# Altium schematic sheet RF_Front-End1.SchDoc
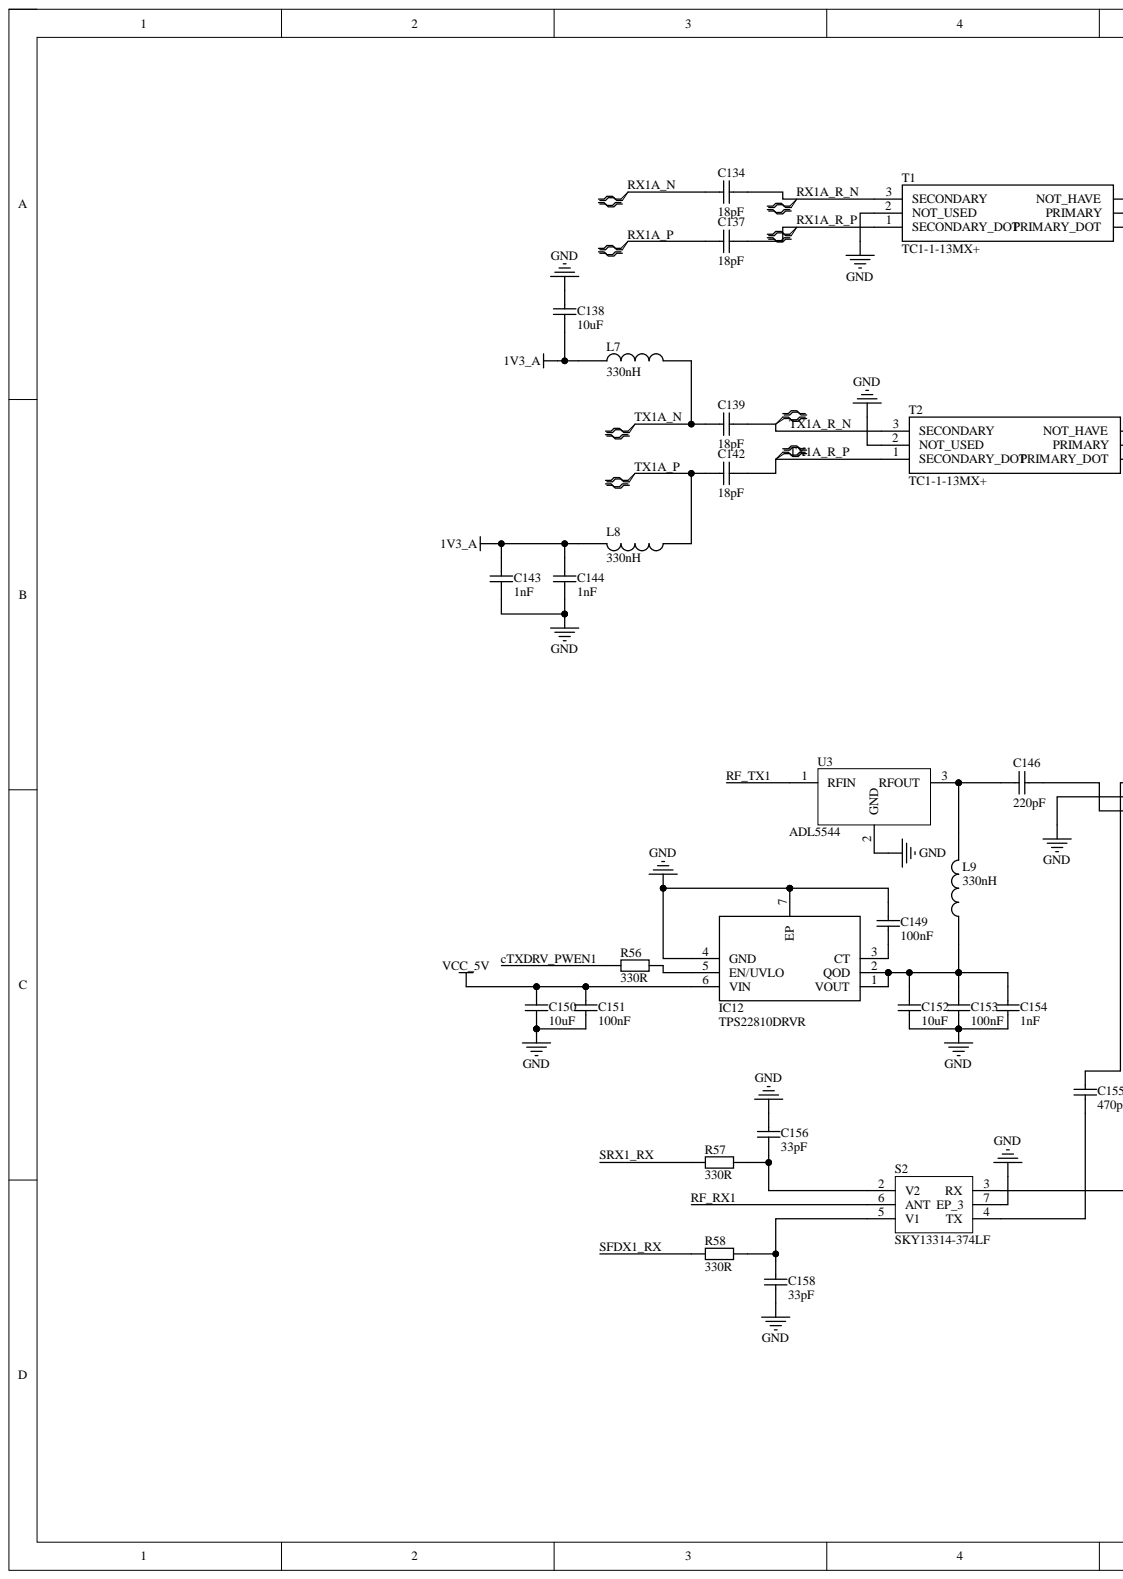
[diagram p0: RF_Front-End1.SchDoc, left]
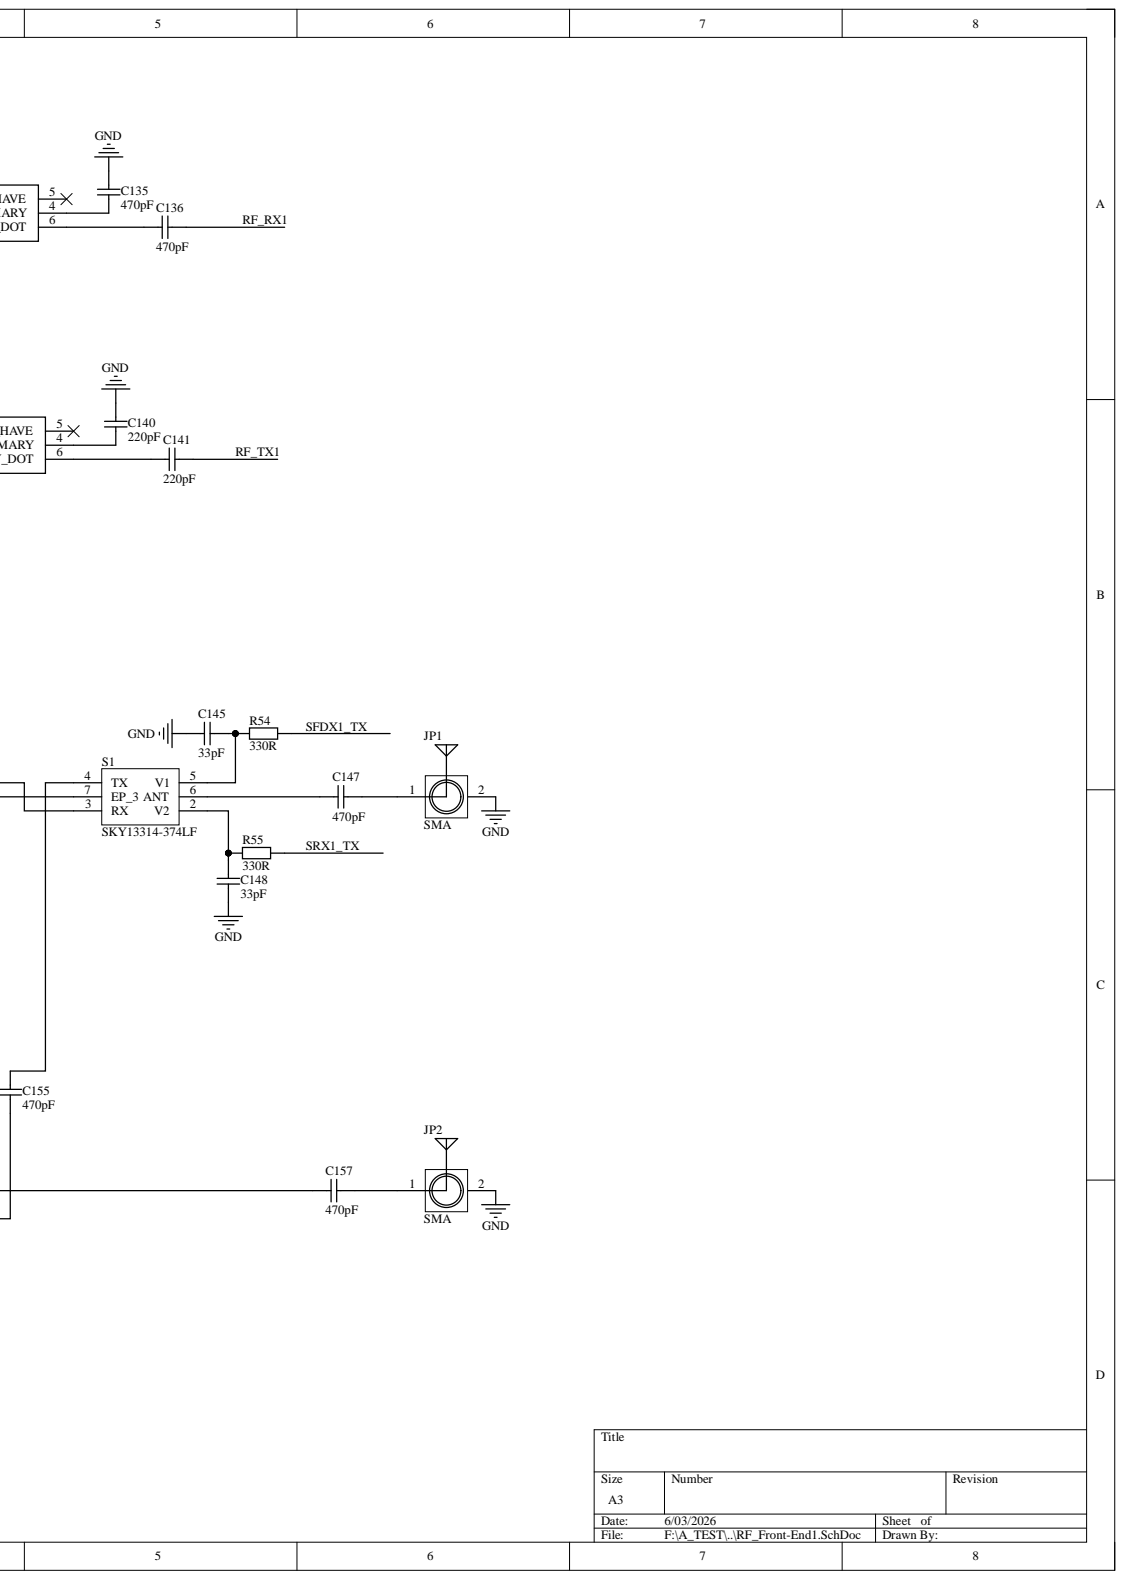
[diagram p1: RF_Front-End1.SchDoc, right]

## Parts
C134  18pF  [C 0402_L]
C135  470pF  [C 0402_L]
C136  470pF  [C 0402_L]
C137  18pF  [C 0402_L]
C138  10uF  [C 0402_L]
C139  18pF  [C 0402_L]
C140  220pF  [C 0402_L]
C141  220pF  [C 0402_L]
C142  18pF  [C 0402_L]
C143  1nF  [C 0402_L]
C144  1nF  [C 0402_L]
C145  33pF  [C 0402_L]
C146  220pF  [C 0402_L]
C147  470pF  [C 0402_L]
C148  33pF  [C 0402_L]
C149  100nF  [C 0402_L]
C150  10uF  [C 0603_L]
C151  100nF  [C 0402_L]
C152  10uF  [C 0603_L]
C153  100nF  [C 0402_L]
C154  1nF  [C 0402_L]
C155  470pF  [C 0402_L]
C156  33pF  [C 0402_L]
C157  470pF  [C 0402_L]
C158  33pF  [C 0402_L]
IC12  TPS22810DRVR  [SON65P200X200X80-7N]
JP1  SMA  [SMA-KE - 0.5mm]
JP2  SMA  [SMA-KE - 0.5mm]
L7  330nH  [L 0402]
L8  330nH  [L 0402]
L9  330nH  [L 0402]
R54  330R  [R 0402_L]
R55  330R  [R 0402_L]
R56  330R  [R 0402_L]
R57  330R  [R 0402_L]
R58  330R  [R 0402_L]
S1  SKY13314-374LF  [SKY13314374LF]
S2  SKY13314-374LF  [SKY13314374LF]
T1  TC1-1-13MX+  [TC414]
T2  TC1-1-13MX+  [TC414]
U3  ADL5544  [SOT89]

## Nets
1V3_A: C138.1 C143.1 C144.1 L7.1 L8.2
GND: C135.1 C138.2 C140.1 C143.2 C144.2 C145.2 C148.2 C149.2 C150.1 C151.1 C152.1 C153.1 C154.1 C156.2 C158.2 IC12.4(GND) IC12.7(EP) JP1.2 JP2.2 S1.7(EP_3) S2.7(EP_3) T1.2(NOT_USED) T2.2(NOT_USED) U3.2
VCC_5V: C150.2 C151.2 IC12.6(VIN)
cTXDRV_PWEN1: R56.1
RF_RX1: C136.2 S2.6(ANT)
RF_TX1: C141.2 U3.1
RX1A_N: C134.1
RX1A_P: C137.1
RX1A_R_N: C134.2 T1.3(SECONDARY)
RX1A_R_P: C137.2 T1.1(SECONDARY_DOT)
SFDX1_RX: R58.1
SFDX1_TX: R54.2
SRX1_RX: R57.1
SRX1_TX: R55.2
TX1A_N: C139.1 L7.2
TX1A_P: C142.1 L8.1
TX1A_R_N: C139.2 T2.3(SECONDARY)
TX1A_R_P: C142.2 T2.1(SECONDARY_DOT)
NetC135_2: C135.2 T1.4(PRIMARY)
NetC136_1: C136.1 T1.6(PRIMARY_DOT)
NetC140_2: C140.2 T2.4(PRIMARY)
NetC141_1: C141.1 T2.6(PRIMARY_DOT)
NetC145_1: C145.1 R54.1 S1.5(V1)
NetC146_1: C146.1 S1.3(RX)
NetC146_2: C146.2 L9.2 U3.3
NetC147_1: C147.1 JP1.1
NetC147_2: C147.2 S1.6(ANT)
NetC148_1: C148.1 R55.1 S1.2(V2)
NetC149_1: C149.1 IC12.3(CT)
NetC152_2: C152.2 C153.2 C154.2 IC12.1(VOUT) IC12.2(QOD) L9.1
NetC155_1: C155.1 S1.4(TX)
NetC155_2: C155.2 S2.4(TX)
NetC156_1: C156.1 R57.2 S2.2(V2)
NetC157_1: C157.1 JP2.1
NetC157_2: C157.2 S2.3(RX)
NetC158_1: C158.1 R58.2 S2.5(V1)
NetIC12_5: IC12.5(EN/UVLO) R56.2

Not connected: T1 5(NOT_HAVE); T2 5(NOT_HAVE)

## Library data of the parts
C134-C158 = Cap: 贴片电容; Component Kind: Standard; Library Name: A_Schlib.SchLib
  C134 C137 C139 C142: value: 18pF
  C135 C136 C147 C155 C157: value: 470pF
  C138 C150 C152: value: 10uF
  C140 C141 C146: value: 220pF
  C143 C144 C154: value: 1nF
  C145 C148 C156 C158: value: 33pF
  C149 C151 C153: value: 100nF
IC12 = TPS22810DRVR: Integrated Circuit; Manufacturer_Name: Texas Instruments; Arrow Part Number: TPS22810DRVR; Arrow Price/Stock: https://www.arrow.com/en/products/tps22810drvr/texas-instruments?region=nac; Component Kind: Standard; Datasheet Link: http://www.ti.com/lit/gpn/tps22810; Height: 0.8mm; Library Name: SamacSys.SchLib; Manufacturer_Part_Number: TPS22810DRVR; Mouser Part Number: 595-TPS22810DRVR; Mouser Price/Stock: https://www.mouser.co.uk/ProductDetail/Texas-Instruments/TPS22810DRVR?qs=5aG0NVq1C4wkfnc1%252B6LezA%3D%3D
JP1 JP2 = SMA: SMA-2.4G射频天线接口; 版权归属: 源创客; BOM名称: SMA-2.4G射频天线接口; Component Kind: Standard; Library Name: A_Schlib.SchLib; 学习资源: www.ooocke.com
L7-L9 = 电感: 包含功率; Component Kind: Standard; Library Name: A_Schlib.SchLib
R54-R58 = Res: 通用; Component Kind: Standard; Library Name: A_Schlib.SchLib; value: 330R
S1 S2 = SKY13314-374LF: Switch; Manufacturer_Name: Skyworks; Component Kind: Standard; Datasheet Link: https://www.skyworksinc.com/-/media/SkyWorks/Documents/Products/101-200/SKY13314_374LF_200882J.pdf; Height: 0.5mm; Library Name: SamacSys.SchLib; Manufacturer_Part_Number: SKY13314-374LF; Mouser Part Number: 873-SKY13314-374LF; Mouser Price/Stock: https://www.mouser.co.uk/ProductDetail/Skyworks-Solutions-Inc/SKY13314-374LF?qs=WMHGlxXAKT%252BJLauIraDVsw%3D%3D
T1 T2 = TC1-1-13M+: Transformer; Manufacturer_Name: Mini-Circuits; Component Kind: Standard; Datasheet Link: https://datasheet.datasheetarchive.com/originals/distributors/Datasheets-28/DSA-546322.pdf; Height: 4.06mm; Library Name: SamacSys.SchLib; Manufacturer_Part_Number: TC1-1-13M+; Mouser Part Number: 139-TC1-1-13M; Mouser Price/Stock: https://www.mouser.co.uk/ProductDetail/Mini-Circuits/TC1-1-13M%2b?qs=xZ%2FP%252Ba9zWqYek%252BZGAF2fWg%3D%3D
U3 = PGA-102+: Component Kind: Standard; Library Name: A_Schlib.SchLib
